# Altium schematic sheet KC4D_EXT_Power_V1.2.SchDoc(KC4D_EXT_Power_V1.2)
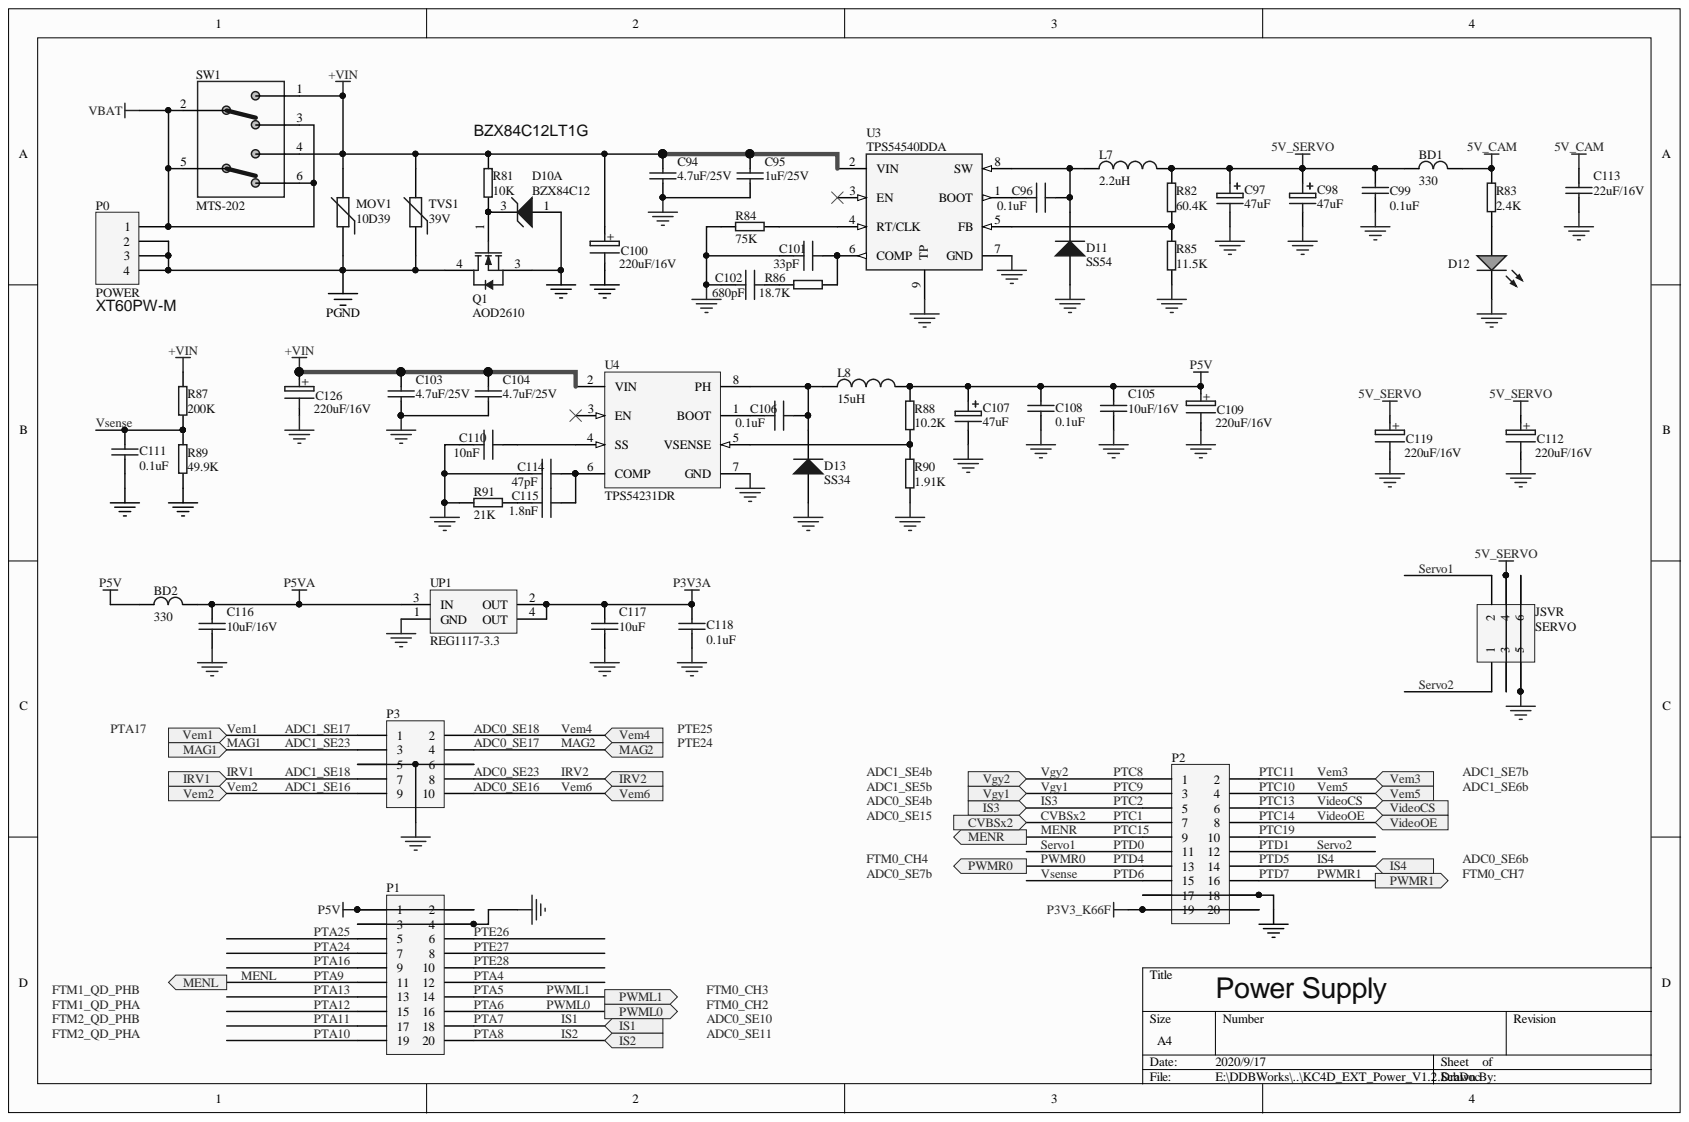
[diagram p0: KC4D_EXT_Power_V1.2.SchDoc]

## Parts
BD1  330  [B0805J]
BD2  330  [B0805J]
C94  4.7uF/25V  [C0805J]
C95  1uF/25V  [C0603J]
C96  0.1uF  [C0603J]
C97  47uF  [LC-1210_C]
C98  47uF  [LC-1210_C]
C99  0.1uF  [C0603J]
C100  220uF/16V  [SMD-EC-F6.3]
C101  33pF  [C0603J]
C102  680pF  [C0603J]
C103  4.7uF/25V  [C0805J]
C104  4.7uF/25V  [C0805J]
C105  10uF/16V  [C0805J]
C106  0.1uF  [C0603J]
C107  47uF  [LC-1210_C]
C108  0.1uF  [C0603J]
C109  220uF/16V  [SMD-EC-F6.3]
C110  10nF  [C0603J]
C111  0.1uF  [C0603J]
C112  220uF/16V  [SMD-EC-F6.3]
C113  22uF/16V  [C0805J]
C114  47pF  [C0603J]
C115  1.8nF  [C0603J]
C116  10uF/16V  [C0805J]
C117  10uF  [C0603J]
C118  0.1uF  [C0603J]
C119  220uF/16V  [SMD-EC-F6.3]
C126  220uF/16V  [SMD-EC-F6.3]
D10  BZX84C12  [SOT23J]  (part A)
D11  SS54  [SMB_DTE]
D12  (no value)  [D0805J]
D13  SS34  [SMB_DTE]
JSVR  SERVO  [HDR2X3H]
L7  2.2uH  [SWPA8040S]
L8  15uH  [SWPA8040S]
MOV1  10D39  [VaristorD10]
P0  POWER  [XT30PW-M]
P1  (no value)  [IDC20X]
P2  (no value)  [IDC20X]
P3  (no value)  [IDC10X]
Q1  AOD2610  [ONSC-DPAK-3-369AA-01_V]
R81  10K  [R0603J]
R82  60.4K  [R0603J]
R83  2.4K  [R0603J]
R84  75K  [R0603J]
R85  11.5K  [R0603J]
R86  18.7K  [R0603J]
R87  200K  [R0603J]
R88  10.2K  [R0603J]
R89  49.9K  [R0603J]
R90  1.91K  [R0603J]
R91  21K  [R0603J]
SW1  MTS-202  [RLS-202-A3-00]
TVS1  39V  [SMB_DTE]
U3  TPS54540DDA  [SOIC8DTE_EP]
U4  TPS54231DR  [D8_N]
UP1  REG1117-3.3  [SOT223DTE]

## Ports
CVBSx2, IRV1, IRV2, IS1, IS2, IS3, IS4, MAG1, MAG2, MENL, MENR, PWML0, PWML1, PWMR0, PWMR1, Vem1, Vem2, Vem3, Vem4, Vem5, Vem6, Vgy1, Vgy2, VideoCS, VideoOE

## Nets
5V_CAM: BD1.2 C113.2 R83.1
5V_SERVO: BD1.1 C97.1 C98.1 C99.2 C112.1 C119.1 JSVR.3 JSVR.4 L7.2 R82.1
+VIN: C94.2 C95.2 C100.1 C103.2 C104.2 C126.1 MOV1.2 R81.1 R87.2 SW1.1 SW1.4 TVS1.2 U3.2(VIN) U4.2(VIN)
GND: C94.1 C95.1 C97.2 C98.2 C99.1 C100.2 C101.2 C102.2 C103.1 C104.1 C105.1 C107.2 C108.1 C109.2 C110.2 C111.1 C112.2 C113.1 C114.2 C116.1 C117.1 C118.1 C119.2 C126.2 D10.1 D11.1 D12.K D13.1 JSVR.5 JSVR.6 P1.3 P1.4 P2.17 P2.18 P3.5 P3.6 Q1.3 R84.1 R85.1 R89.1 R90.1 R91.1 U3.7(GND) U3.9(TP) U4.7(GND) UP1.1(GND)
P3V3_K66F: P2.19 P2.20
P3V3A: C117.2 C118.2 UP1.2(OUT) UP1.4(OUT)
P5V: BD2.1 C105.2 C107.1 C108.2 C109.1 L8.2 P1.1 P1.2 R88.1
P5VA: BD2.2 C116.2 UP1.3(IN)
PGND: MOV1.1 P0.2 P0.3 P0.4 Q1.4 TVS1.1
VBAT: P0.1 SW1.2 SW1.3 SW1.5 SW1.6
ADC0_SE16: P3.10 ; port Vem6
ADC0_SE17: P3.4 ; port MAG2
ADC0_SE18: P3.2 ; port Vem4
ADC0_SE23: P3.8 ; port IRV2
ADC1_SE16: P3.9 ; port Vem2
ADC1_SE17: P3.1 ; port Vem1
ADC1_SE18: P3.7 ; port IRV1
ADC1_SE23: P3.3 ; port MAG1
CVBSx2: P2.7 ; port CVBSx2
IS1: P1.18 ; port IS1
IS2: P1.20 ; port IS2
IS3: P2.5 ; port IS3
IS4: P2.14 ; port IS4
MENL: P1.11 ; port MENL
MENR: P2.9 ; port MENR
PTA4: P1.12
PTA5: P1.14 ; port PWML1
PTA6: P1.16 ; port PWML0
PTA10: P1.19
PTA11: P1.17
PTA12: P1.15
PTA13: P1.13
PTA16: P1.9
PTA24: P1.7
PTA25: P1.5
PTC8: P2.1 ; port Vgy2
PTC9: P2.3 ; port Vgy1
PTC10: P2.4 ; port Vem5
PTC11: P2.2 ; port Vem3
PTC13: P2.6 ; port VideoCS
PTC14: P2.8 ; port VideoOE
PTC19: P2.10
PTD0: JSVR.2 P2.11
PTD1: JSVR.1 P2.12
PTD4: P2.13 ; port PWMR0
PTD6: C111.2 P2.15 R87.1 R89.2
PTD7: P2.16 ; port PWMR1
PTE26: P1.6
PTE27: P1.8
PTE28: P1.10
NetC96_1: C96.1 D11.2 L7.1 U3.8(SW)
NetC96_2: C96.2 U3.1(BOOT)
NetC101_1: C101.1 R86.2 U3.6(COMP)
NetC102_1: C102.1 R86.1
NetC106_1: C106.1 D13.2 L8.1 U4.8(PH)
NetC106_2: C106.2 U4.1(BOOT)
NetC110_1: C110.1 U4.4(SS)
NetC114_1: C114.1 C115.1 U4.6(COMP)
NetC115_2: C115.2 R91.2
NetD10_3: D10.3 Q1.1 R81.2
NetD12_A: D12.A R83.2
NetR82_2: R82.2 R85.2 U3.5(FB)
NetR84_2: R84.2 U3.4(RT/CLK)
NetR88_2: R88.2 R90.2 U4.5(VSENSE)
ADC0_SE4b:
ADC0_SE6b:
ADC0_SE7b:
ADC0_SE10:
ADC0_SE11:
ADC0_SE15:
ADC1_SE4b:
ADC1_SE5b:
ADC1_SE6b:
ADC1_SE7b:
FTM0_CH2:
FTM0_CH3:
FTM0_CH4:
FTM0_CH7:
FTM1_QD_PHA:
FTM1_QD_PHB:
FTM2_QD_PHA:
FTM2_QD_PHB:
PTA17:
PTE24:
PTE25:

Not connected: U3 3(EN); U4 3(EN)

## Library data of the parts
BD1 BD2 = BEADSMD: PCB3D: DI1206_0_0000_D; Library Name: DTE1.SchLib; Component Kind: Standard
C94 C95 C99 C103-C105 C108 C111 C113 C116-C118 = CAP: PCB3D: 0805_0_0000_C; Part Field 4: 30pF; Part Field 3: Altium Limited; Part Field 2: July-2002: Re-released for DXP Platform.; Part Field 1: 8-Jun-2000; Component Kind: Standard
C96 C101 C102 C106 C110 C114 C115 = Ca_DTE: Library Name: DTE1.SchLib; Component Kind: Standard
C97 C98 C107 = CAPPOLSMD: Component Kind: Standard
C100 C109 C112 C119 C126 = Cb_DTE: PCB3D: C3216-1206_0_0000_C; Library Name: DTE1.SchLib; Component Kind: Standard
D10 = BZX84C12LT1G: Zener Voltage Regulator, 225 mW, 3-Pin SOT-23, Pb-Free, Tape and Reel; Manufacturer: On Semiconductor; Supplier Part Number 9: 863-BZX84C12LT1G; Supplier Part Number 8: 67R1296; Supplier Part Number 7: 83H6453; Supplier Part Number 6: 2317723; Supplier Part Number 5: 1459049RL; Supplier Part Number 4: 1459049; Supplier Part Number 3: BZX84C12LT1GOSDKR-ND; Supplier Part Number 2: BZX84C12LT1GOSCT-ND; Supplier Part Number 1: BZX84C12LT1GOSTR-ND; Supplier 9: Mouser; Supplier 8: Newark; Supplier 7: Newark; Supplier 6: Farnell; Supplier 5: Farnell; Supplier 4: Farnell; Supplier 3: Digi-Key; Supplier 2: Digi-Key; Supplier 1: Digi-Key; RoHS: Pb-Free; PartNumber: BZX84C12LT1G; Packing: Tape and Reel; PackageVersion: Rev. AP, 11/2009; PackageReference: SOT-23-3-318-08; PackageDescription: 3-Pin Small Outline Transistor, Body 2.92 x 1.3 mm, Pitch 0.95 mm; Mounting Technology: Surface Mount; Library Name: ON Semi Zener Diodes.IntLib; DatasheetVersion: Rev. 17; ComponentLink3URL: http://www.onsemi.cn/pub_link/Collateral/318-08.PDF; ComponentLink3Description: Package Specification; ComponentLink2URL: http://www.onsemi.com/pub_link/Collateral/BZX84C2V4LT1-D.PDF; ComponentLink2Description: Datasheet; ComponentLink1URL: http://www.onsemi.com/; ComponentLink1Description: Manufacturer URL; Component Kind: Standard
D11 D13 = SMA(DO-214AC) SS54: 二极管; SuppliersPartNumber: C22452; Supplier: LC; Package: SMA(DO-214AC); Library Name: Miscellaneous Devices LC.IntLib; ComponentLink1URL: http://www.szlcsc.com/product/details_23178.html; ComponentLink1Description: 供应商链接; Component Kind: Standard
D12 = 0805 翠绿: 发光二极管; SuppliersPartNumber: C2297; Supplier: LC; Package: 0805; Library Name: Miscellaneous Devices LC.IntLib; ComponentLink1URL: http://www.szlcsc.com/product/details_2670.html; ComponentLink1Description: 供应商链接; Component Kind: Standard
JSVR = Header 3X2H: Header, 3-Pin, Dual row, Right Angle; Signal Integrity: Connector; Library Name: Miscellaneous Connectors.IntLib; LatestRevisionNote: Re-released for DXP Platform.; LatestRevisionDate: 17-Jul-2002; Component Kind: Standard; Publisher: Altium Limited
L7 L8 = Inductor: Value: C10148; Code_IEC: 1005; Simulation: INDUCTOR; Signal Integrity: Inductor; Published: 8-Jun-2000; PackageReference: 0402-A; PackageDescription: Chip Inductor; Library Name: MyLib.IntLib; LatestRevisionNote: IPC-7351 Footprint Added.; LatestRevisionDate: 29-May-2009; Description: Inductor; Component Kind: Standard; Code_JEITA: 0402; Publisher: Altium Limited
MOV1 TVS1 = Res Varistor: Varistor (Voltage-Sensitive Resistor); Published: 24-Mar-1999; PackageReference: 6-0805; Library Name: Miscellaneous Devices.IntLib; LatestRevisionNote: PCB Footprint replaced.; LatestRevisionDate: 04-Mar-2005; Component Kind: Standard; Publisher: Altium Limited
P0 = Header 4: Header, 4-Pin; Signal Integrity: Connector; Library Name: Miscellaneous Connectors.IntLib; LatestRevisionNote: Re-released for DXP Platform.; LatestRevisionDate: 17-Jul-2002; Component Kind: Standard; Publisher: Altium Limited
P1 P2 = Header 10X2: Header, 10-Pin, Dual row; Signal Integrity: Connector; Library Name: Miscellaneous Connectors.IntLib; LatestRevisionNote: Re-released for DXP Platform.; LatestRevisionDate: 17-Jul-2002; Component Kind: Standard; Publisher: Altium Limited
P3 = Header 5X2: Header, 5-Pin, Dual row; Signal Integrity: Connector; Library Name: Miscellaneous Connectors.IntLib; LatestRevisionNote: Re-released for DXP Platform.; LatestRevisionDate: 17-Jul-2002; Component Kind: Standard; Publisher: Altium Limited
Q1 = NTD4858NT4G: Power MOSFET, 25 V, 73 A, Single N-Channel, 3-Pin DPAK, Pb-Free, Tape and Reel; Manufacturer: On Semiconductor; VGS Max (V): 20; VGS(th) Max (V): 2.5; V(BR)DSS Min (V): 25; Qrr Typ (nC): 3; ID Max (A): 73; Crss Typ (pF): 200; Coss Typ (pF): 405; Ciss Typ (pF): 1563; Channel Polarity: N Channel; RoHS: Pb-Free; PartNumber: NTD4858NT4G; Packing: Tape and Reel; PackageVersion: Rev. B, 06/2010; Package Type: DPAK-3, IPAK-3; PackageReference: DPAK-3-369AA-01; PackageDescription: 3-Pin Flange Mount, Body 6.54 x 6.095 mm, Pitch 2.29 mm; Mounting Technology: Surface Mount; Library Name: ON Semi MOSFETs.IntLib; DatasheetVersion: Rev. 2; Configuration: Single; ComponentLink3URL: http://www.onsemi.cn/pub_link/Collateral/369AA.PDF; ComponentLink3Description: Package Specification; ComponentLink2URL: http://www.onsemi.cn/pub_link/Collateral/NTD4858N-D.PDF; ComponentLink2Description: Datasheet; ComponentLink1URL: http://www.onsemi.com/; ComponentLink1Description: Manufacturer URL; Component Kind: Standard
R81-R91 = Ra_DTE: Library Name: DTE1.SchLib; Component Kind: Standard
  R81 R87 R89: PCB3D: 0805_0_0000_R
SW1 = TS8-202A2: Library Name: DTE1.SchLib; Component Kind: Standard
U3 = TPS54340DDA: Library Name: DTE_LibS.SchLib; Component Kind: Standard
U4 = TPS54331DR: Buck Step Down Regulator with 3.5 to 28 V Input and 0.8 to 25 V Output, -40 to 150 degC, 8-Pin SOIC (D), Green (RoHS & no Sb/Br); Manufacturer: Texas Instruments; Vout(Min)(V): 0.8; Vout(Max)(V): 25; Vin(Min)(V): 3.5; Vin(Max)(V): 28; SubFamily: Step-Down Regulator; Regulated Outputs(#): 1; Rating: Catalog; Iq(Typ)(mA): 0.11; Iout(Max)(A): 3; Duty Cycle(Max)(%): 90; Topology: Buck; Switch Current Limit(Typ)(A): 3.5; Supplier Part Number 5: 595-TPS54331DR; Supplier Part Number 4: 03P5202; Supplier Part Number 3: 296-26991-6-ND; Supplier Part Number 2: 296-26991-1-ND; Supplier Part Number 1: 296-26991-2-ND; Supplier 5: Mouser; Supplier 4: Newark; Supplier 3: Digi-Key; Supplier 2: Digi-Key; Supplier 1: Digi-Key; PartNumber: TPS54331DR; PackageVersion: revM, Jun-2011; PackageReference: D8; PackageDescription: 8-Pin Plastic Small Outline Integrated Circuit, 4.9 x 3.9 mm, Pitch 1.27 mm Pitch; Mounting Technology: Surface Mount; Library Name: TI Power Management Step-Down Regulator.IntLib; Eco-Plan: Green (RoHS & no Sb/Br); DatasheetVersion: SLVS839D; ComponentLink3URL: Samples URL:http://www.ti.com/product/tps54331#samples; ComponentLink3Description: URL Link1; ComponentLink2URL: http://www.ti.com/litv/pdf/msoi002j; ComponentLink2Description: Package Specification; ComponentLink1URL: http://www.ti.com/general/docs/lit/getliterature.tsp?genericPartNumber=TPS54331&fileType=pdf; ComponentLink1Description: Datasheet; Component Kind: Standard; Code_JEDEC: MS-012AA
UP1 = REG1117-3.3: 800mA and 1A Low Dropout Positive Regulator 2.85V, 3.3V, 5V, and Adjustable; Published: 8-Jun-2000; PackageVersion: revC; PackageReference: ZZ311; PackageDescription: SOT-223/SC-73; 4 Leads; Body 6.7 x 7.3 mm, inc. leads (LxW max); Library Name: BB Power Mgt Voltage Regulator.IntLib; LatestRevisionNote: Re-released for DXP Platform.; LatestRevisionDate: 17-Jul-2002; DatasheetVersion: Sep-1997; ComponentLink2URL: http://www-s.ti.com/sc/ds/REG1117.pdf; ComponentLink2Description: Datasheet; ComponentLink1URL: http://www.ti.com/; ComponentLink1Description: Manufacturer Link; Component Kind: Standard; Publisher: Altium Limited
